# Altium schematic sheet power.SchDoc(power)
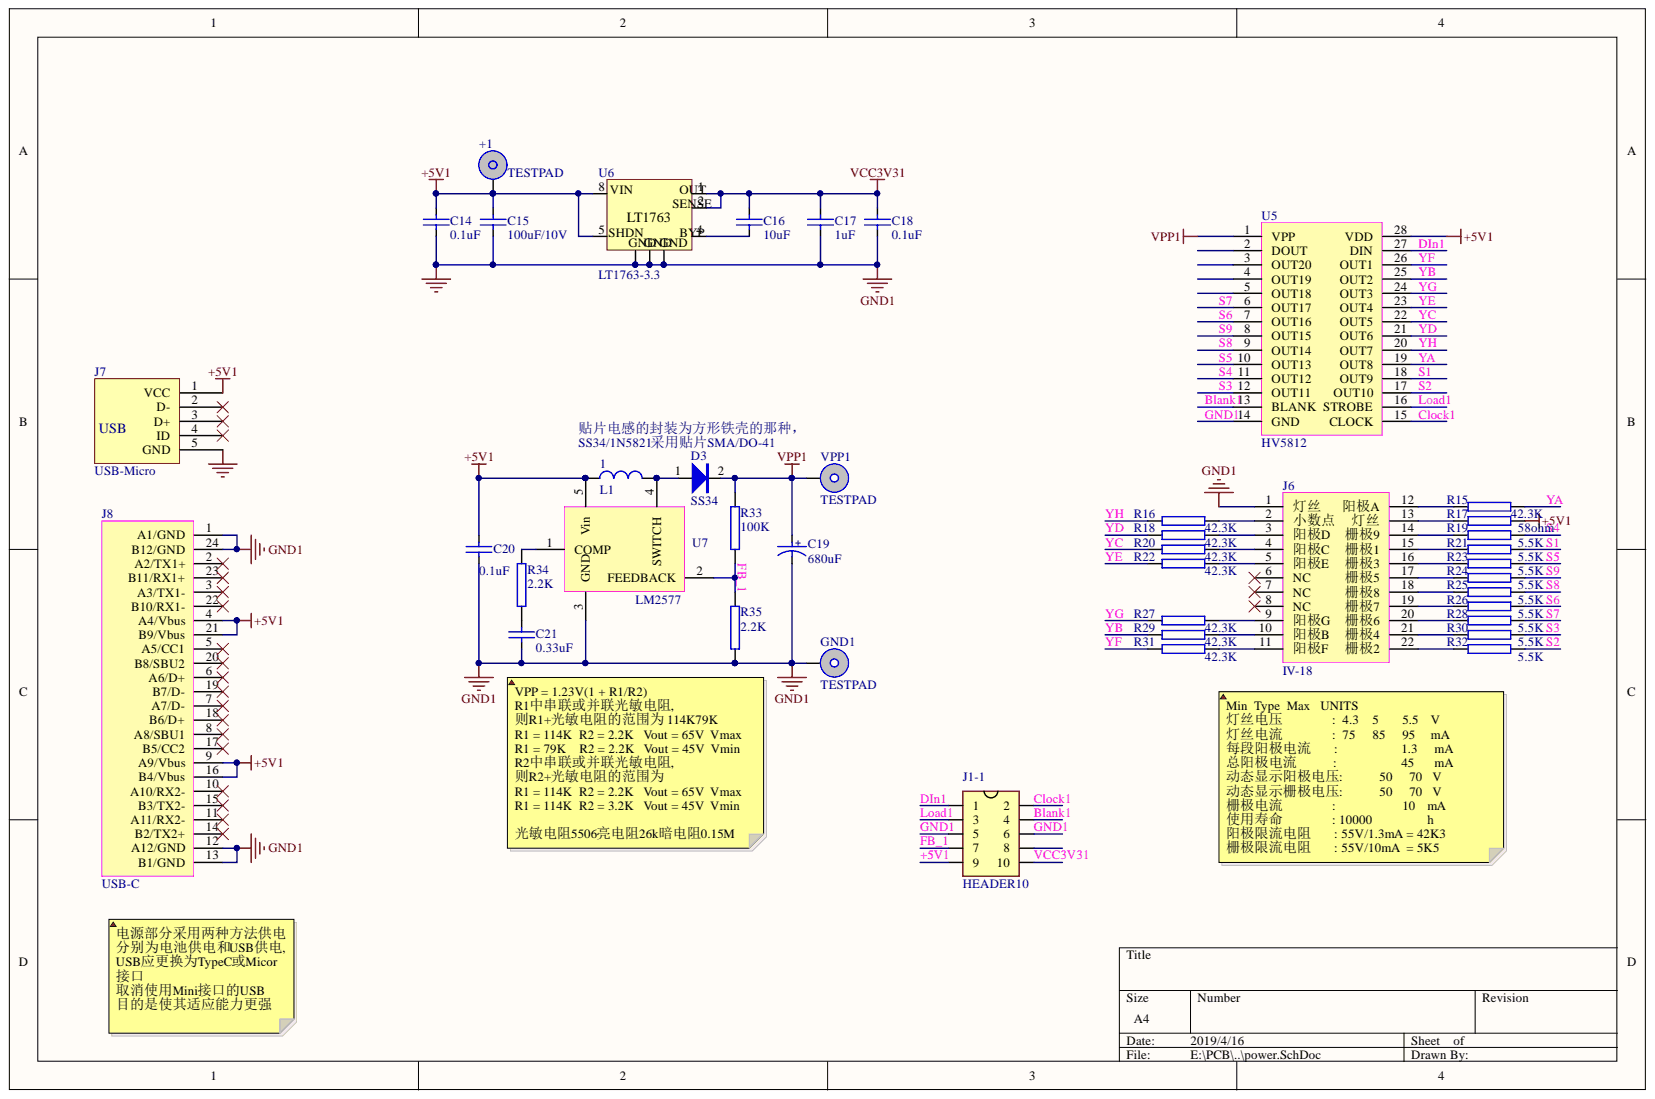
[diagram p0: power.SchDoc]

## Parts
1  L1  [L-101]
+1  TESTPAD  [TP-1.5]
C14  0.1uF  [C0603]
C15  100uF/10V  [C1210]
C16  10uF  [C0603]
C17  1uF  [C0603]
C18  0.1uF  [C0603]
C19  680uF  [CAP200]
C20  0.1uF  [C0603]
C21  0.33uF  [C0603]
D3  SS34  [D1210]
GND1  TESTPAD  [TP-1.5]
J1-1  HEADER10  [HEAD100-10]
J6  IV-18  [IV-18]
J7  USB-Micro  [usb-micro]
J8  USB-C  [USB-C]
R15  42.3K  [R0603]
R16  42.3K  [R0603]
R17  58ohm  [R0603]
R18  42.3K  [R0603]
R19  5.5K  [R0603]
R20  42.3K  [R0603]
R21  5.5K  [R0603]
R22  42.3K  [R0603]
R23  5.5K  [R0603]
R24  5.5K  [R0603]
R25  5.5K  [R0603]
R26  5.5K  [R0603]
R27  42.3K  [R0603]
R28  5.5K  [R0603]
R29  42.3K  [R0603]
R30  5.5K  [R0603]
R31  42.3K  [R0603]
R32  5.5K  [R0603]
R33  100K  [R0603]
R34  2.2K  [R0603]
R35  2.2K  [R0603]
U5  HV5812  [SOP-28]
U6  LT1763-3.3  [SOP-8]
U7  LM2577  [TO-263-5]
VPP1  TESTPAD  [TP-1.5]

## Nets
VPP1: C19.1 D3.2 R33.2 U5.1(VPP) VPP1.1
+5V1: 1.1 +1.1 C14.2 C15.2 C20.2 J1-1.9 J7.1(VCC) J8.4(A4/Vbus) J8.9(A9/Vbus) J8.16(B4/Vbus) J8.21(B9/Vbus) R17.1 U5.28(VDD) U6.5(SHDN) U6.8(VIN) U7.5(Vin)
BLANK1: J1-1.4 U5.13(BLANK)
CLOCK1: J1-1.2 U5.15(CLOCK)
DIN1: J1-1.1 U5.27(DIN)
FB_1: J1-1.7 R33.1 R35.2 U7.2(FEEDBACK)
GND1: C14.1 C15.1 C17.1 C18.1 C19.2 C20.1 C21.1 GND1.1 J1-1.5 J1-1.6 J6.1(灯丝) J7.5(GND) J8.1(A1/GND) J8.12(A12/GND) J8.13(B1/GND) J8.24(B12/GND) R35.1 U5.14(GND) U6.3 U6.6 U6.7 U7.3(GND)
LOAD1: J1-1.3 U5.16(STROBE)
S1: R21.2 U5.18(OUT9)
S2: R32.2 U5.17(OUT10)
S3: R30.2 U5.12(OUT11)
S4: R19.2 U5.11(OUT12)
S5: R23.2 U5.10(OUT13)
S6: R26.2 U5.7(OUT16)
S7: R28.2 U5.6(OUT17)
S8: R25.2 U5.9(OUT14)
S9: R24.2 U5.8(OUT15)
VCC3V31: C16.2 C17.2 C18.2 J1-1.10 U6.1 U6.2
YA: R15.1 U5.19(OUT8)
YB: R29.2 U5.25(OUT2)
YC: R20.2 U5.22(OUT5)
YD: R18.2 U5.21(OUT6)
YE: R22.2 U5.23(OUT4)
YF: R31.2 U5.26(OUT1)
YG: R27.2 U5.24(OUT3)
YH: R16.2 U5.20(OUT7)
Net1_2: 1.2 D3.1 U7.4(SWITCH)
NetC16_1: C16.1 U6.4
NetC21_2: C21.2 R34.1
NetJ6_2: J6.2(小数点) R16.1
NetJ6_3: J6.3(阳极) R18.1
NetJ6_4: J6.4(阳极) R20.1
NetJ6_5: J6.5(阳极) R22.1
NetJ6_9: J6.9(阳极) R27.1
NetJ6_10: J6.10(阳极) R29.1
NetJ6_11: J6.11(阳极) R31.1
NetJ6_12: J6.12(A) R15.2
NetJ6_13: J6.13(灯丝) R17.2
NetJ6_14: J6.14 R19.1
NetJ6_15: J6.15 R21.1
NetJ6_16: J6.16 R23.1
NetJ6_17: J6.17 R24.1
NetJ6_18: J6.18 R25.1
NetJ6_19: J6.19 R26.1
NetJ6_20: J6.20 R28.1
NetJ6_21: J6.21 R30.1
NetJ6_22: J6.22 R32.1
NetR34_2: R34.2 U7.1(COMP)

Not connected: J1-1 8; J6 6(NC) 7(NC) 8(NC); J7 2(D-) 3(D+) 4(ID); J8 2(A2/TX1+) 3(A3/TX1-) 5(A5/CC1) 6(A6/D+) 7(A7/D-) 8(A8/SBU1) 10(A10/RX2-) 11(A11/RX2-) 14(B2/TX2+) 15(B3/TX2-) 17(B5/CC2) 18(B6/D+) 19(B7/D-) 20(B8/SBU2) 22(B10/RX1-) 23(B11/RX1+); U5 2(DOUT) 3(OUT20) 4(OUT19) 5(OUT18)

## Library data of the parts
1 = INDUCTOR: Component Kind: Standard; Library Name: MySchlib.SchLib
C14-C18 C20 C21 = CAP: Component Kind: Standard
  C20 C21: Library Name: MySchlib.SchLib
C19 = CAPE: Component Kind: Standard; Library Name: MySchlib.SchLib
D3 = DIODE: Component Kind: Standard; Library Name: MySchlib.SchLib
R15-R35 = RES: Component Kind: Standard; Library Name: MySchlib.SchLib
U6 = LT1763: Component Kind: Standard
+1 GND1 VPP1 = TESTPAD: Component Kind: Standard; Library Name: MySchlib.SchLib
J1-1 = HEADER10: Component Kind: Standard; Library Name: MySchlib.SchLib
J6 = IV-18: Component Kind: Standard; Library Name: MySchlib.SchLib
J7 = USB-Micro: Component Kind: Standard; Library Name: MySchlib.SchLib
J8 = USB-C: Component Kind: Standard; Library Name: MySchlib.SchLib
U5 = HV5812: Component Kind: Standard; Library Name: MySchlib.SchLib
U7 = LM2577: Component Kind: Standard; Library Name: MySchlib.SchLib
